# Altium schematic sheet CPU.SchDoc
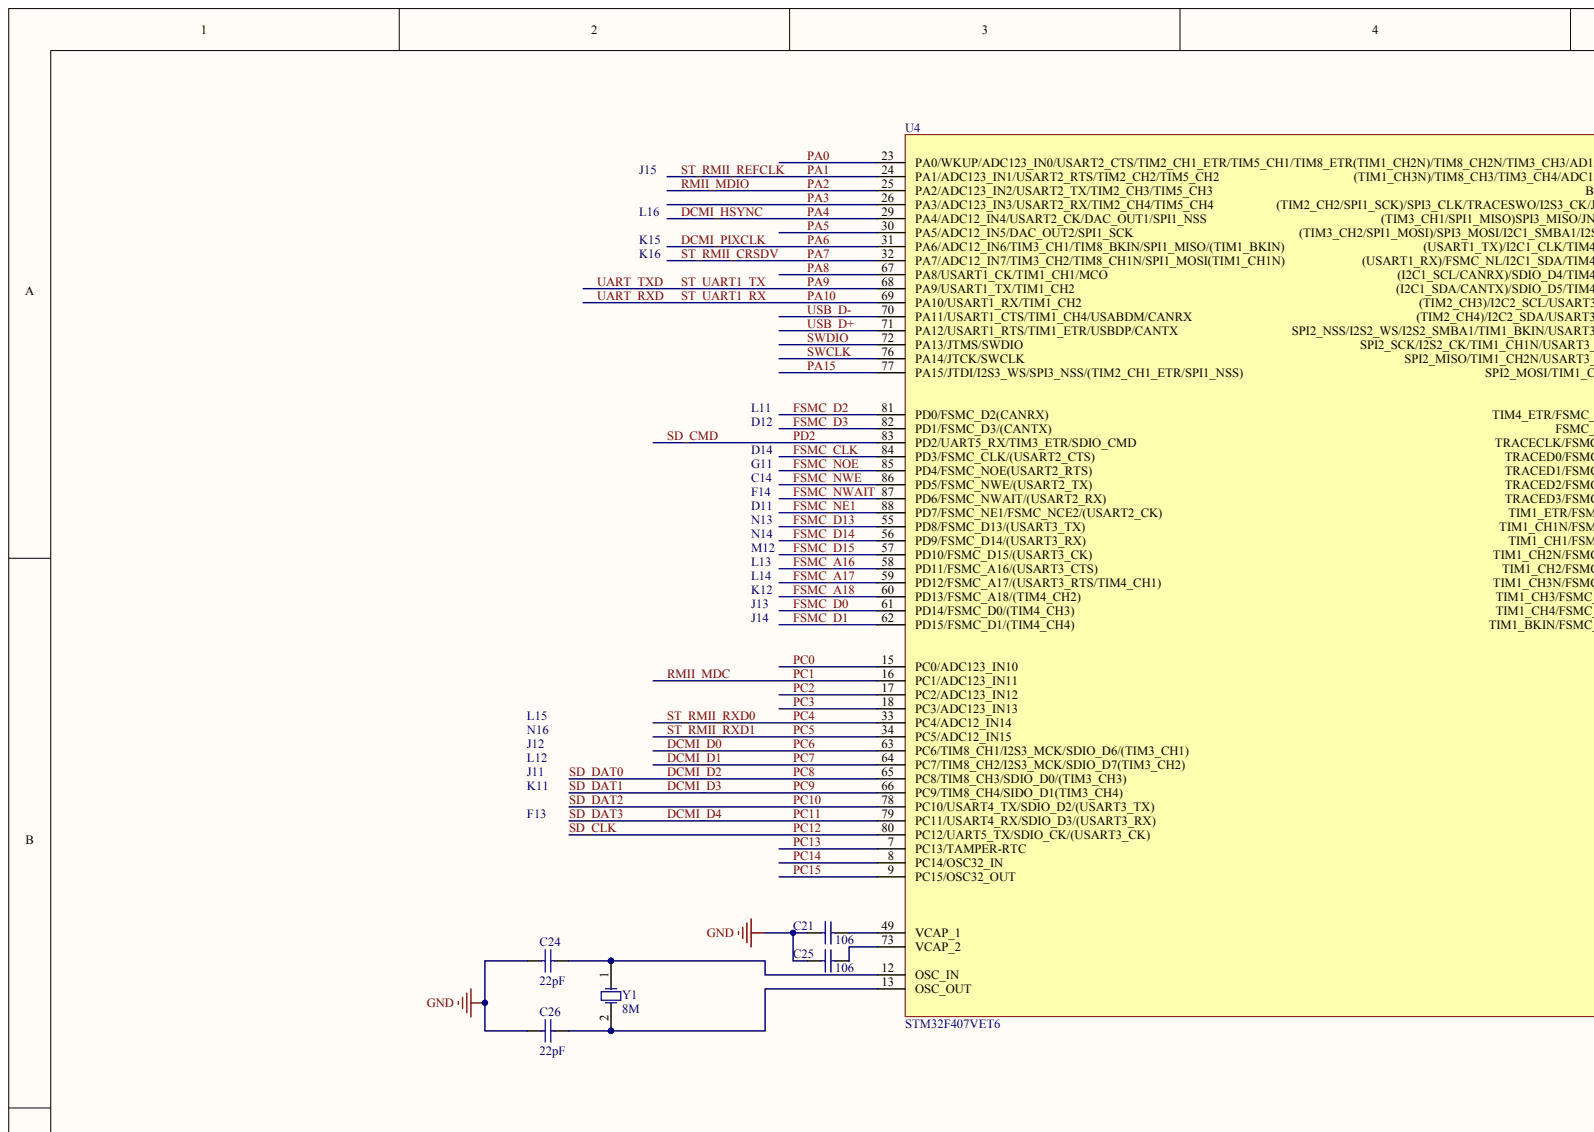
[diagram p0: CPU.SchDoc, top left]
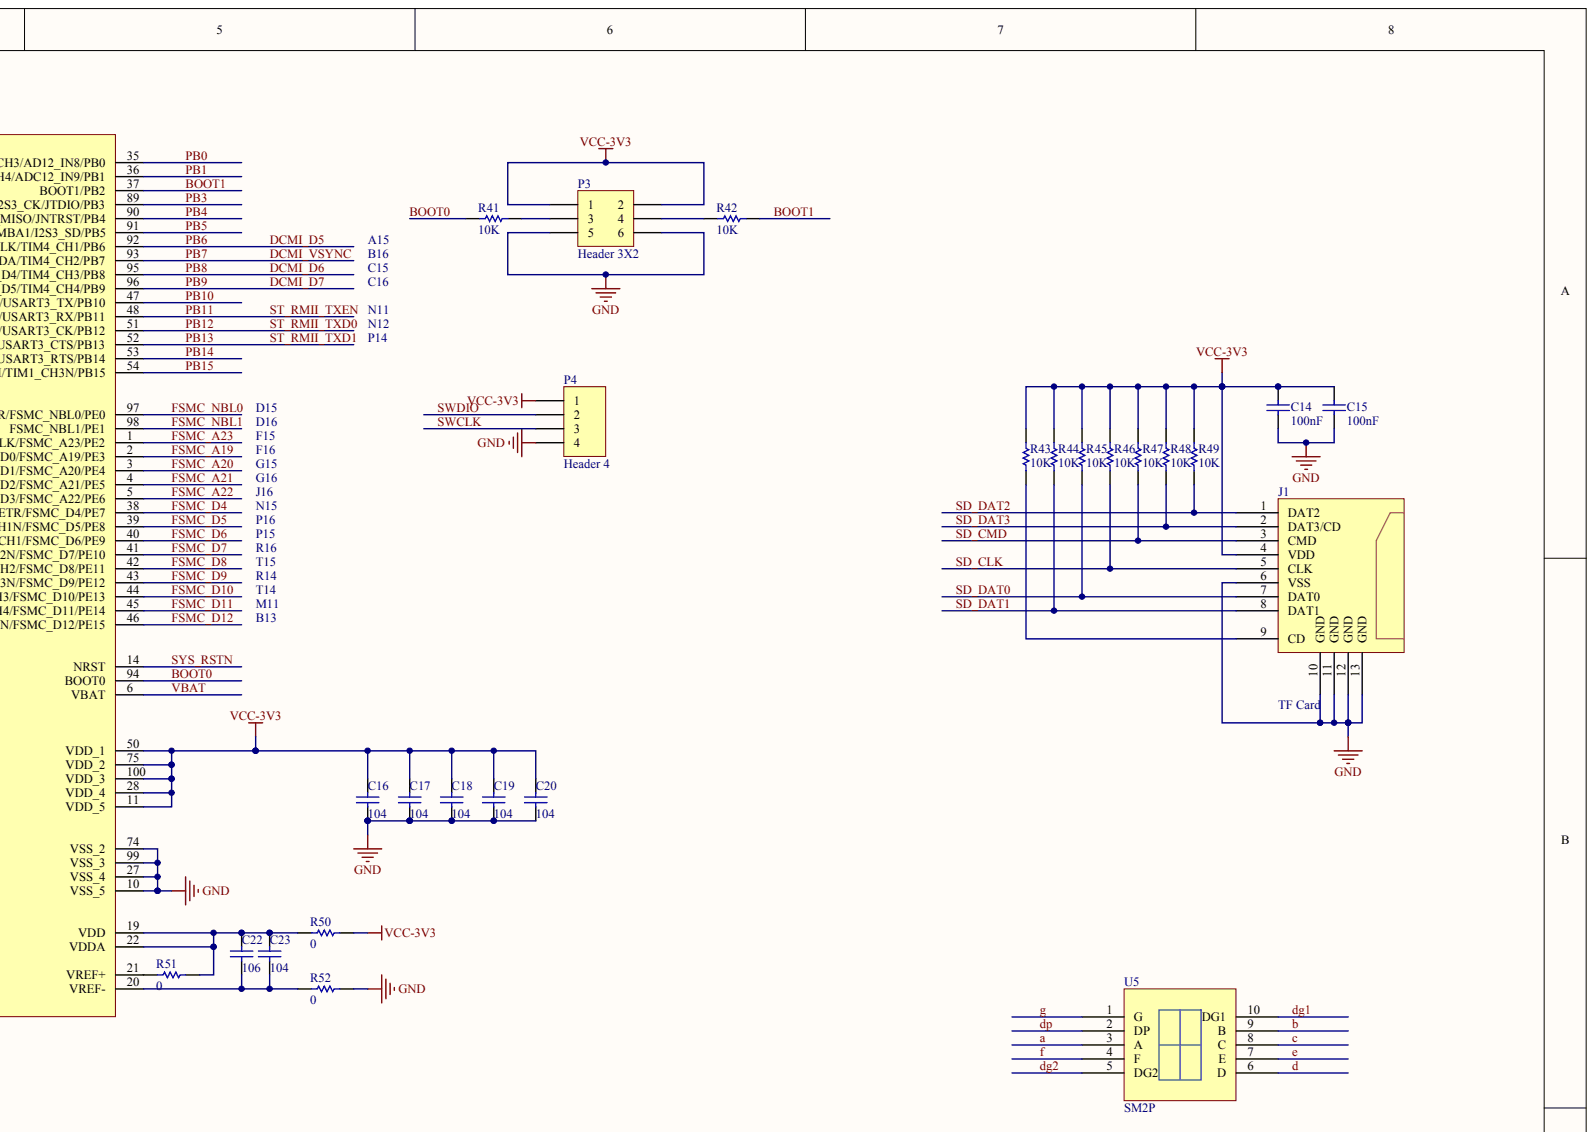
[diagram p1: CPU.SchDoc, top right]
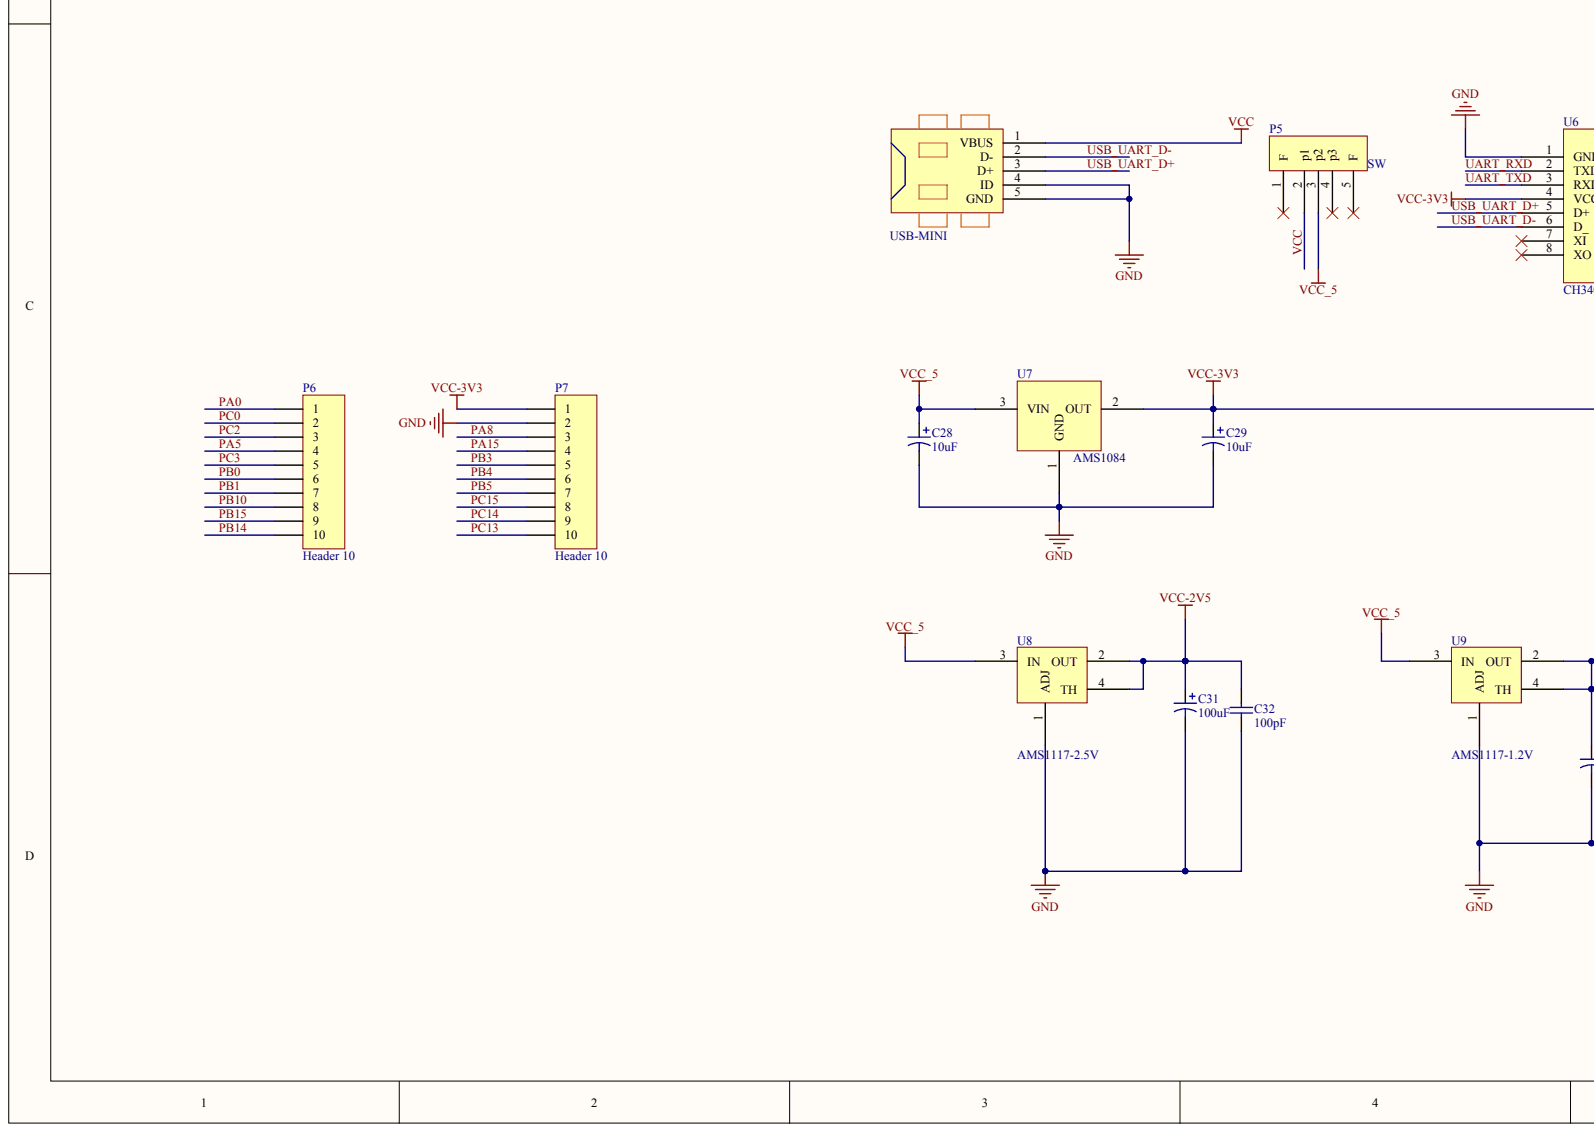
[diagram p2: CPU.SchDoc, bottom left]
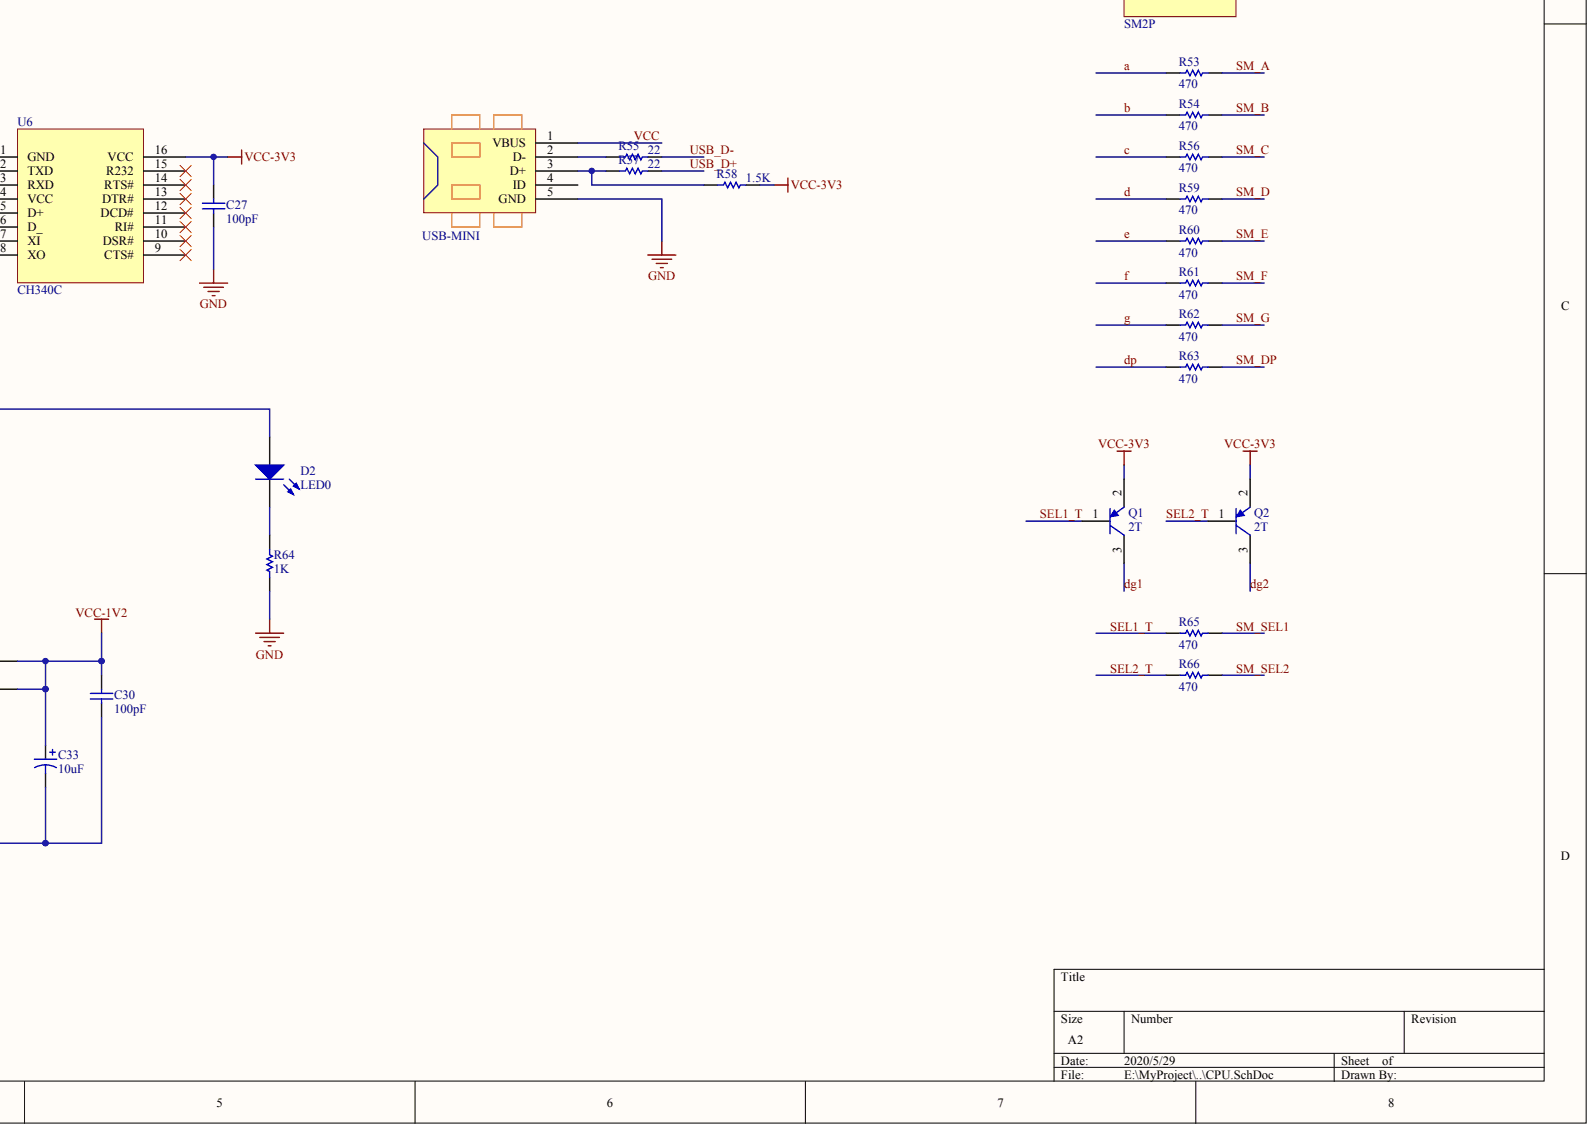
[diagram p3: CPU.SchDoc, bottom right]

## Parts
C14  value 100nF  (hidden comment: Cap)
C15  value 100nF  (hidden comment: Cap)
C16  value 104  (hidden comment: Cap)
C17  value 104  (hidden comment: Cap)
C18  value 104  (hidden comment: Cap)
C19  value 104  (hidden comment: Cap)
C20  value 104  (hidden comment: Cap)
C21  value 106  (hidden comment: Cap)
C22  value 106  (hidden comment: Cap)
C23  value 104  (hidden comment: Cap)
C24  value 22pF  (hidden comment: Cap)
C25  value 106  (hidden comment: Cap)
C26  value 22pF  (hidden comment: Cap)
C27  value 100pF  (hidden comment: Cap)
C28  value 10uF  (hidden comment: Cap Pol1)
C29  value 10uF  (hidden comment: Cap Pol1)
C30  value 100pF  (hidden comment: Cap)
C31  value 100uF  (hidden comment: Cap Pol1)
C32  value 100pF  (hidden comment: Cap)
C33  value 10uF  (hidden comment: Cap Pol1)
D2  LED0
J1  TF Card
P3  Header 3X2
P4  Header 4
P5  SW
P6  Header 10
P7  Header 10
Q1  2T
Q2  2T
R41  value 10K  (hidden comment: Res1)
R42  value 10K  (hidden comment: Res1)
R43  value 10K  (hidden comment: Res1)
R44  value 10K  (hidden comment: Res1)
R45  value 10K  (hidden comment: Res1)
R46  value 10K  (hidden comment: Res1)
R47  value 10K  (hidden comment: Res1)
R48  value 10K  (hidden comment: Res1)
R49  value 10K  (hidden comment: Res1)
R50  value 0  (hidden comment: Res1)
R51  value 0  (hidden comment: Res1)
R52  value 0  (hidden comment: Res1)
R53  value 470  (hidden comment: Res1)
R54  value 470  (hidden comment: Res1)
R55  value 22  (hidden comment: Res1)
R56  value 470  (hidden comment: Res1)
R57  value 22  (hidden comment: Res1)
R58  value 1.5K  (hidden comment: Res1)
R59  value 470  (hidden comment: Res1)
R60  value 470  (hidden comment: Res1)
R61  value 470  (hidden comment: Res1)
R62  value 470  (hidden comment: Res1)
R63  value 470  (hidden comment: Res1)
R64  value 1K  (hidden comment: Res1)
R65  value 470  (hidden comment: Res1)
R66  value 470  (hidden comment: Res1)
U4  STM32F407VET6
U5  SM2P
U6  CH340C
U7  AMS1084
U8  AMS1117-2.5V
U9  AMS1117-1.2V
USB-MINI1  USB-MINI
USB-MINI2  USB-MINI
Y1  8M

## Nets
GND: C14.2 C15.1 C16.2 C17.2 C18.2 C19.2 C20.2 C21.2 C24.1 C25.2 C26.1 C27.1 C28.2 C29.2 C30.1 C31.2 C32.1 C33.2 J1.6(VSS) J1.10(GND) J1.11(GND) J1.12(GND) J1.13(GND) P3.5 P3.6 P4.4 P7.2 R52.1 R64.1 U4.10(VSS_5) U4.27(VSS_4) U4.74(VSS_2) U4.99(VSS_3) U6.1(GND) U7.1 U8.1(ADJ) U9.1(ADJ) USB-MINI1.4(ID) USB-MINI1.5(GND) USB-MINI2.5(GND)
VCC-1V2: C30.2 C33.1 U9.2(OUT) U9.4(TH)
VCC-2V5: C31.1 C32.2 U8.2(OUT) U8.4(TH)
VCC-3V3: C14.1 C15.2 C16.1 C17.1 C18.1 C19.1 C20.1 C27.2 C29.1 D2.1 J1.4(VDD) P3.1 P3.2 P4.1 P7.1 Q1.2 Q2.2 R43.2 R44.2 R45.2 R46.2 R47.2 R48.2 R49.2 R50.1 R58.1 U4.11(VDD_5) U4.28(VDD_4) U4.50(VDD_1) U4.75(VDD_2) U4.100(VDD_3) U6.4(VCC) U6.16(VCC) U7.2
VCC_5: C28.1 P5.3(p2) U7.3 U8.3(IN) U9.3(IN)
A: R53.2 U5.3(A)
B: R54.2 U5.9(B)
BOOT0: R41.2 U4.94(BOOT0)
BOOT1: R42.1 U4.37(BOOT1/PB2)
C: R56.2 U5.8(C)
D: R59.2 U5.6(D)
DCMI_D0: U4.63(PC6/TIM8_CH1/I2S3_MCK/SDIO_D6/(TIM3_CH1))
DCMI_D1: U4.64(PC7/TIM8_CH2/I2S3_MCK/SDIO_D7(TIM3_CH2))
DCMI_D2: J1.7(DAT0) R45.1 U4.65(PC8/TIM8_CH3/SDIO_D0/(TIM3_CH3))
DCMI_D3: J1.8(DAT1) R44.1 U4.66(PC9/TIM8_CH4/SIDO_D1(TIM3_CH4))
DCMI_D4: J1.2(DAT3/CD) R48.1 U4.79(PC11/USART4_RX/SDIO_D3/(USART3_RX))
DCMI_D5: U4.92((USART1_TX)/I2C1_CLK/TIM4_CH1/PB6)
DCMI_D6: U4.95((I2C1_SCL/CANRX)/SDIO_D4/TIM4_CH3/PB8)
DCMI_D7: U4.96((I2C1_SDA/CANTX)/SDIO_D5/TIM4_CH4/PB9)
DCMI_HSYNC: U4.29(PA4/ADC12_IN4/USART2_CK/DAC_OUT1/SPI1_NSS)
DCMI_PIXCLK: U4.31(PA6/ADC12_IN6/TIM3_CH1/TIM8_BKIN/SPI1_MISO/(TIM1_BKIN))
DCMI_VSYNC: U4.93((USART1_RX)/FSMC_NL/I2C1_SDA/TIM4_CH2/PB7)
DG1: Q1.3 U5.10(DG1)
DG2: Q2.3 U5.5(DG2)
DP: R63.2 U5.2(DP)
E: R60.2 U5.7(E)
F: R61.2 U5.4(F)
FSMC_A16: U4.58(PD11/FSMC_A16/(USART3_CTS))
FSMC_A17: U4.59(PD12/FSMC_A17/(USART3_RTS/TIM4_CH1))
FSMC_A18: U4.60(PD13/FSMC_A18/(TIM4_CH2))
FSMC_A19: U4.2(TRACED0/FSMC_A19/PE3)
FSMC_A20: U4.3(TRACED1/FSMC_A20/PE4)
FSMC_A21: U4.4(TRACED2/FSMC_A21/PE5)
FSMC_A22: U4.5(TRACED3/FSMC_A22/PE6)
FSMC_A23: U4.1(TRACECLK/FSMC_A23/PE2)
FSMC_CLK: U4.84(PD3/FSMC_CLK/(USART2_CTS))
FSMC_D0: U4.61(PD14/FSMC_D0/(TIM4_CH3))
FSMC_D1: U4.62(PD15/FSMC_D1/(TIM4_CH4))
FSMC_D2: U4.81(PD0/FSMC_D2(CANRX))
FSMC_D3: U4.82(PD1/FSMC_D3/(CANTX))
FSMC_D4: U4.38(TIM1_ETR/FSMC_D4/PE7)
FSMC_D5: U4.39(TIM1_CH1N/FSMC_D5/PE8)
FSMC_D6: U4.40(TIM1_CH1/FSMC_D6/PE9)
FSMC_D7: U4.41(TIM1_CH2N/FSMC_D7/PE10)
FSMC_D8: U4.42(TIM1_CH2/FSMC_D8/PE11)
FSMC_D9: U4.43(TIM1_CH3N/FSMC_D9/PE12)
FSMC_D10: U4.44(TIM1_CH3/FSMC_D10/PE13)
FSMC_D11: U4.45(TIM1_CH4/FSMC_D11/PE14)
FSMC_D12: U4.46(TIM1_BKIN/FSMC_D12/PE15)
FSMC_D13: U4.55(PD8/FSMC_D13/(USART3_TX))
FSMC_D14: U4.56(PD9/FSMC_D14/(USART3_RX))
FSMC_D15: U4.57(PD10/FSMC_D15/(USART3_CK))
FSMC_NBL0: U4.97(TIM4_ETR/FSMC_NBL0/PE0)
FSMC_NBL1: U4.98(FSMC_NBL1/PE1)
FSMC_NE1: U4.88(PD7/FSMC_NE1/FSMC_NCE2/(USART2_CK))
FSMC_NOE: U4.85(PD4/FSMC_NOE(USART2_RTS))
FSMC_NWAIT: U4.87(PD6/FSMC_NWAIT/(USART2_RX))
FSMC_NWE: U4.86(PD5/FSMC_NWE/(USART2_TX))
G: R62.2 U5.1(G)
PA0: P6.1 U4.23(PA0/WKUP/ADC123_IN0/USART2_CTS/TIM2_CH1_ETR/TIM5_CH1/TIM8_ETR)
PA1: U4.24(PA1/ADC123_IN1/USART2_RTS/TIM2_CH2/TIM5_CH2)
PA2: U4.25(PA2/ADC123_IN2/USART2_TX/TIM2_CH3/TIM5_CH3)
PA3: U4.26(PA3/ADC123_IN3/USART2_RX/TIM2_CH4/TIM5_CH4)
PA5: P6.4 U4.30(PA5/ADC12_IN5/DAC_OUT2/SPI1_SCK)
PA7: U4.32(PA7/ADC12_IN7/TIM3_CH2/TIM8_CH1N/SPI1_MOSI(TIM1_CH1N))
PA8: P7.3 U4.67(PA8/USART1_CK/TIM1_CH1/MCO)
PA9: U4.68(PA9/USART1_TX/TIM1_CH2) U6.3(RXD)
PA10: U4.69(PA10/USART1_RX/TIM1_CH2) U6.2(TXD)
PA15: P7.4 U4.77(PA15/JTDI/I2S3_WS/SPI3_NSS/(TIM2_CH1_ETR/SPI1_NSS))
PB0: P6.6 U4.35((TIM1_CH2N)/TIM8_CH2N/TIM3_CH3/AD12_IN8/PB0)
PB1: P6.7 U4.36((TIM1_CH3N)/TIM8_CH3/TIM3_CH4/ADC12_IN9/PB1)
PB3: P7.5 U4.89((TIM2_CH2/SPI1_SCK)/SPI3_CLK/TRACESWO/I2S3_CK/JTDIO/PB3)
PB4: P7.6 U4.90((TIM3_CH1/SPI1_MISO)SPI3_MISO/JNTRST/PB4)
PB5: P7.7 U4.91((TIM3_CH2/SPI1_MOSI)/SPI3_MOSI/I2C1_SMBA1/I2S3_SD/PB5)
PB10: P6.8 U4.47((TIM2_CH3)/I2C2_SCL/USART3_TX/PB10)
PB11: U4.48((TIM2_CH4)/I2C2_SDA/USART3_RX/PB11)
PB12: U4.51(SPI2_NSS/I2S2_WS/I2S2_SMBA1/TIM1_BKIN/USART3_CK/PB12)
PB13: U4.52(SPI2_SCK/I2S2_CK/TIM1_CH1N/USART3_CTS/PB13)
PB14: P6.10 U4.53(SPI2_MISO/TIM1_CH2N/USART3_RTS/PB14)
PB15: P6.9 U4.54(SPI2_MOSI/TIM1_CH3N/PB15)
PC0: P6.2 U4.15(PC0/ADC123_IN10)
PC1: U4.16(PC1/ADC123_IN11)
PC2: P6.3 U4.17(PC2/ADC123_IN12)
PC3: P6.5 U4.18(PC3/ADC123_IN13)
PC4: U4.33(PC4/ADC12_IN14)
PC5: U4.34(PC5/ADC12_IN15)
PC10: J1.1(DAT2) R49.1 U4.78(PC10/USART4_TX/SDIO_D2/(USART3_TX))
PC12: J1.5(CLK) R46.1 U4.80(PC12/UART5_TX/SDIO_CK/(USART3_CK))
PC13: P7.10 U4.7(PC13/TAMPER-RTC)
PC14: P7.9 U4.8(PC14/OSC32_IN)
PC15: P7.8 U4.9(PC15/OSC32_OUT)
PD2: J1.3(CMD) R47.1 U4.83(PD2/UART5_RX/TIM3_ETR/SDIO_CMD)
SEL1_T: Q1.1 R65.2
SEL2_T: Q2.1 R66.2
SM_A: R53.1
SM_B: R54.1
SM_C: R56.1
SM_D: R59.1
SM_DP: R63.1
SM_E: R60.1
SM_F: R61.1
SM_G: R62.1
SM_SEL1: R65.1
SM_SEL2: R66.1
SWCLK: P4.3 U4.76(PA14/JTCK/SWCLK)
SWDIO: P4.2 U4.72(PA13/JTMS/SWDIO)
SYS_RSTN: U4.14(NRST)
USB_D+: R57.1 U4.71(PA12/USART1_RTS/TIM1_ETR/USBDP/CANTX)
USB_D-: R55.1 U4.70(PA11/USART1_CTS/TIM1_CH4/USABDM/CANRX)
USB_UART_D+: U6.5(D+) USB-MINI1.3(D+)
USB_UART_D-: U6.6(D_) USB-MINI1.2(D-)
VBAT: U4.6(VBAT)
VCC: P5.2(p1) USB-MINI1.1(VBUS) USB-MINI2.1(VBUS)
NetC21_1: C21.1 U4.49(VCAP_1)
NetC22_1: C22.1 C23.1 R50.2 R51.1 U4.19(VDD) U4.22(VDDA)
NetC22_2: C22.2 C23.2 R52.2 U4.20(VREF-)
NetC24_2: C24.2 U4.12(OSC_IN) Y1.1
NetC25_1: C25.1 U4.73(VCAP_2)
NetC26_2: C26.2 U4.13(OSC_OUT) Y1.2
NetD2_2: D2.2 R64.2
NetJ1_9: J1.9(CD) R43.1
NetP3_3: P3.3 R41.1
NetP3_4: P3.4 R42.2
NetR51_2: R51.2 U4.21(VREF+)
NetR55_2: R55.2 USB-MINI2.2(D-)
NetR57_2: R57.2 R58.2 USB-MINI2.3(D+)

Not connected: P5 1(F) 4(p3) 5(F); U6 7(XI) 8(XO) 9(CTS#) 10(DSR#) 11(RI#) 12(DCD#) 13(DTR#) 14(RTS#) 15(R232); USB-MINI2 4(ID)

## Library data of the parts
C14-C33 D2 J1 P3-P7 Q1 Q2 R41-R66 U4-U9 USB-MINI1 USB-MINI2 Y1 = (no symbol name)
  C14-C27 C30 C32: Published: 8-Jun-2000; LatestRevisionDate: 17-Jul-2002; LatestRevisionNote: Re-released for DXP Platform.; PackageReference: RAD-0.3; PackageDescription: Radial Cap, Thru-Hole; 2 Leads; 0.3 in Pin Spacing
  C28 C29 C31 C33: Published: 8-Jun-2000; LatestRevisionDate: 17-Jul-2002; LatestRevisionNote: Re-released for DXP Platform.; PackageReference: RB7.6-15; PackageDescription: Polarized Capacitor (Radial); 2 Leads
  D2: Published: 8-Jun-2000; LatestRevisionDate: 08-Jul-2005; LatestRevisionNote: Mech Layer 1 removed.; PackageReference: LED-0; PackageDescription: LED; 2 Leads
  P3 P4 P6 P7: LatestRevisionDate: 17-Jul-2002; LatestRevisionNote: Re-released for DXP Platform.
  Q1 Q2: Published: 8-Jun-2000; LatestRevisionDate: 29-May-2009; LatestRevisionNote: IPC-7351 Footprint Added.; PackageVersion: Aug-1999; PackageReference: SOT-23B; PackageDescription: 3-Pin SOT-23 Package 0.95 mm Pitch, 2.4 mm Lead Span
  R41-R66: Published: 8-Jun-2000; LatestRevisionDate: 17-Jul-2002; LatestRevisionNote: Re-released for DXP Platform.; PackageReference: AXIAL-0.3; PackageDescription: Axial Device, Thru-Hole; 2 Leads; 0.3 in Pin Spacing
  Y1: Published: 8-Jun-2000; LatestRevisionDate: 17-Jul-2002; LatestRevisionNote: Re-released for DXP Platform.; PackageReference: R38; PackageDescription: Crystal, Thru-Hole; 2 Leads; Body 3.1 x 8.2 mm (Dia.xH)
  C14-C33 D2 P3 P4 P6 P7 Q1 Q2 R41-R66 Y1: Publisher: Altium Limited
